# Altium schematic sheet TUCH-ALM.SchDoc(TUCH-ALM)
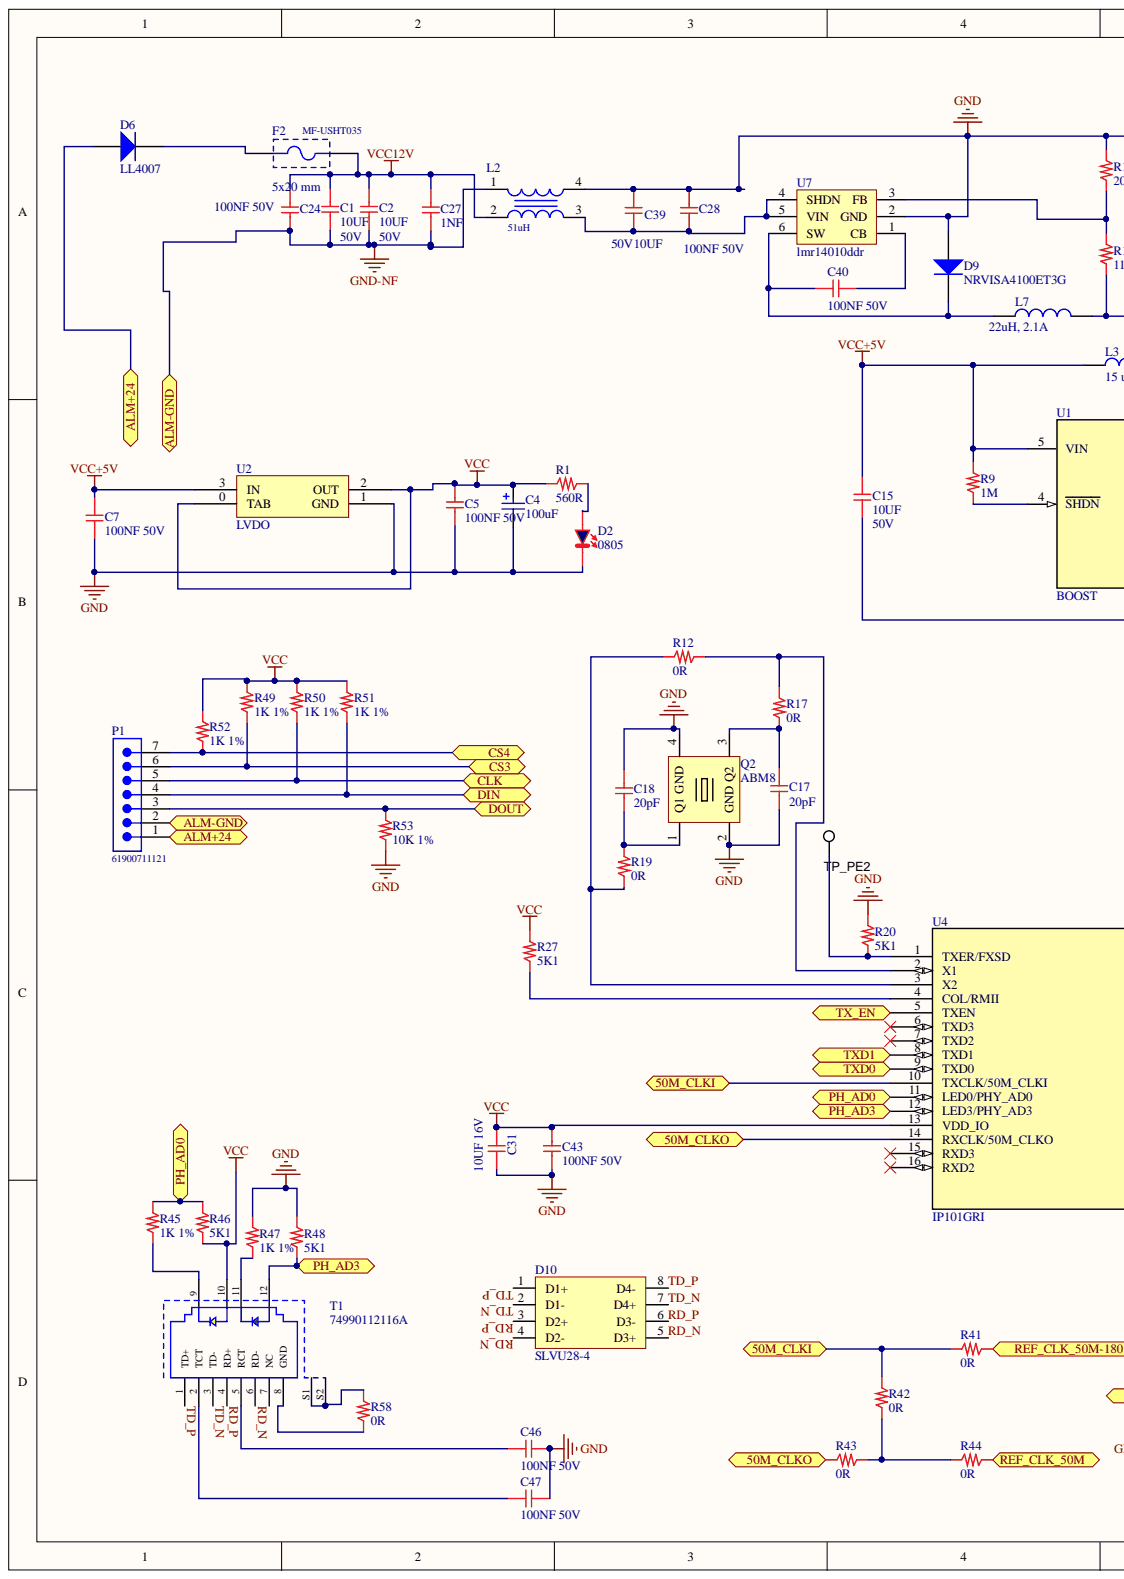
[diagram p0: TUCH-ALM.SchDoc, left]
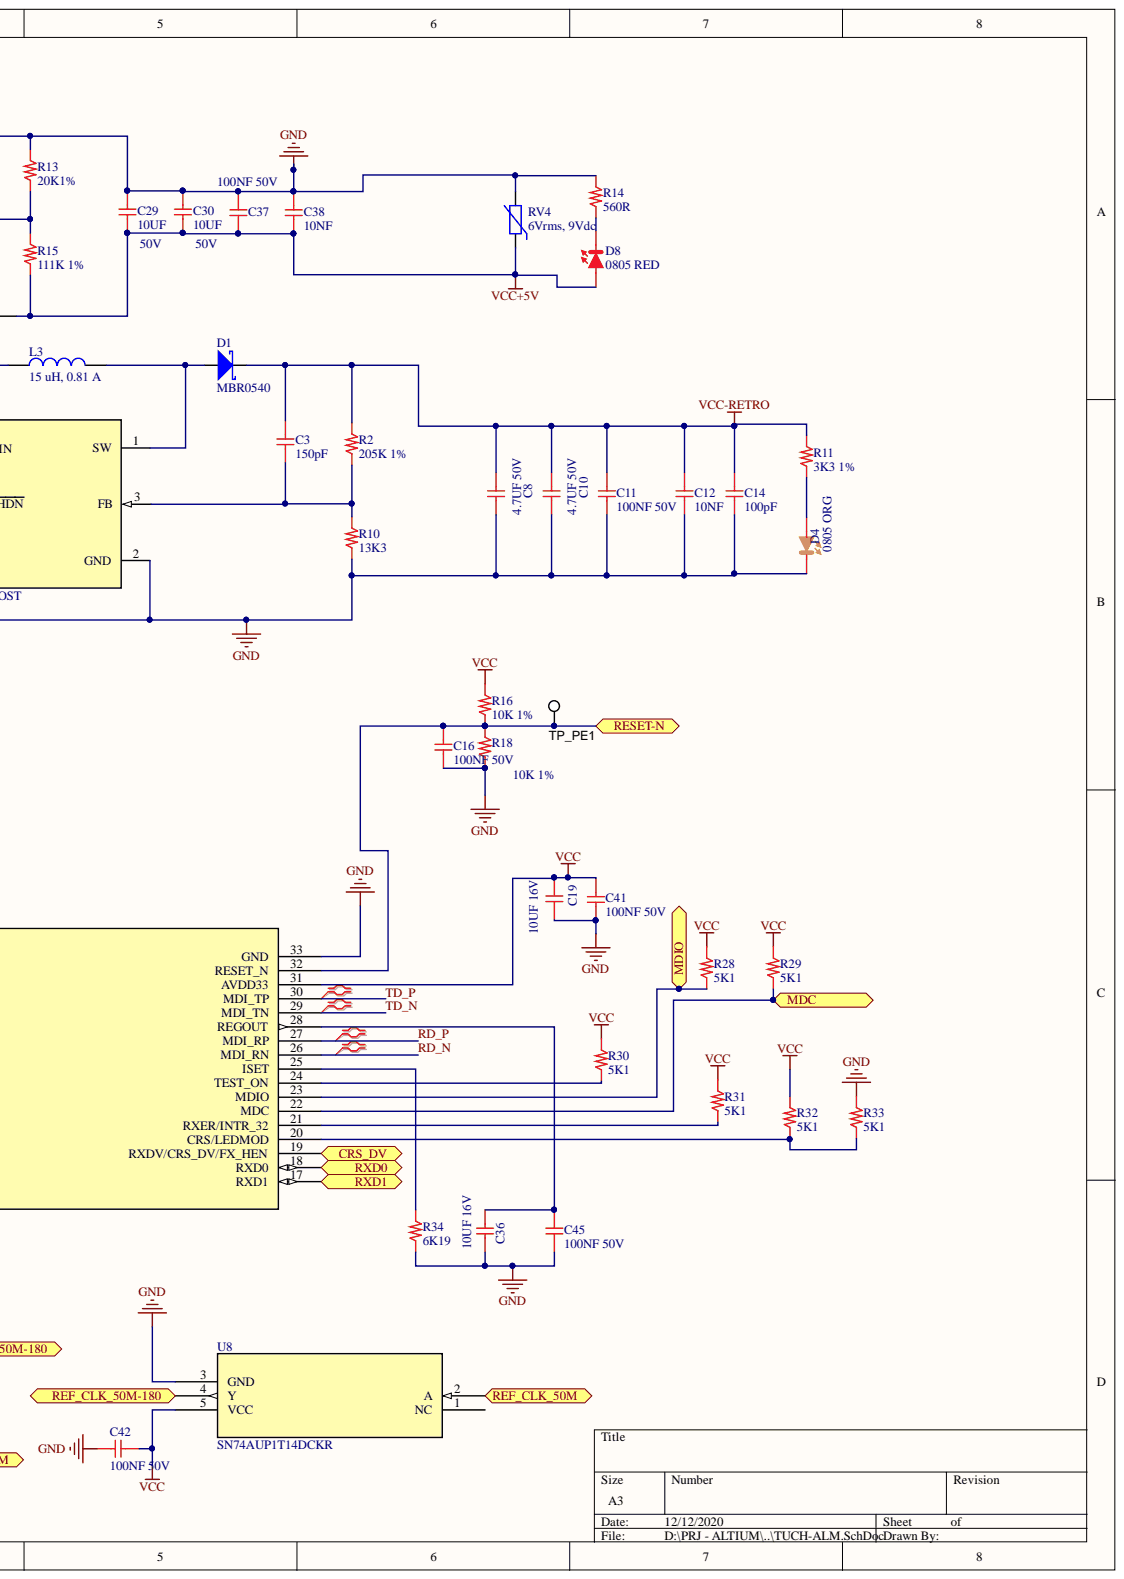
[diagram p1: TUCH-ALM.SchDoc, right]

## Parts
C1  10UF  Tensione: 50V  [1206_C]
C2  10UF  Tensione: 50V  [1206_C]
C3  150pF  [0603_c]
C4  value 100uF  [WCAP-ASLL_6.3x5.5]  (hidden comment: 865060143004)
C5  100NF 50V  [0603_c]
C7  100NF 50V  [0603_c]
C8  4.7UF 50V  [0805_C]
C10  4.7UF 50V  [0805_C]
C11  100NF 50V  [0603_c]
C12  10NF  [0603_c]
C14  100pF  [0603_c]
C15  10UF  Tensione: 50V  [1206_C]
C16  100NF 50V  [0603_c]
C17  20pF  [0402_C]
C18  20pF  [0402_C]
C19  10UF 16V  [0805_C]
C24  100NF 50V  [0603_c]
C27  1NF  [0603_c]
C28  100NF 50V  [0603_c]
C29  10UF  Tensione: 50V  [1206_C]
C30  10UF  Tensione: 50V  [1206_C]
C31  10UF 16V  [0805_C]
C36  10UF 16V  [0805_C]
C37  100NF 50V  [0603_c]
C38  10NF  [0603_c]
C39  10UF  Tensione: 50V  [1206_C]
C40  100NF 50V  [0603_c]
C41  100NF 50V  [0603_c]
C42  100NF 50V  [0603_c]
C43  100NF 50V  [0603_c]
C45  100NF 50V  [0603_c]
C46  100NF 50V  [0603_c]
C47  100NF 50V  [0603_c]
D1  MBR0540  [SMB]
D2  0805  [0805-BLU]
D4  0805 ORG  [0805_Orange]
D6  LL4007  [LL4007]
D8  0805 RED  [0805_Red]
D9  NRVISA4100ET3G  [DO-214AC -B]
D10  SLVU28-4  [SO-8]
F2  MF-USHT035  [MF-USHT035]
L2  51uH  [WE-SL2]
L3  15 uH, 0.81 A  Rated Current: 0.81 A  [WE-LQS_3012]
L7  22uH, 2.1A  Rated Current: 2.1A  [WE-LQS_8040]
P1  61900711121  [61900711121]
Q2  ABM8  [ABM8]
R1  560R  [0603_R]
R2  205K 1%  [0603_R]
R9  1M  [0603_R]
R10  13K3  [0603_R]
R11  3K3 1%  [0805_R]
R12  0R  [0402_R]
R13  20K1%  [0603_R]
R14  560R  [0603_R]
R15  111K 1%  [0603_R]
R16  10K 1%  [0603_R]
R17  0R  [0402_R]
R18  10K 1%  [0603_R]
R19  0R  [0402_R]
R20  5K1  [0402_R]
R27  5K1  [0402_R]
R28  5K1  [0402_R]
R29  5K1  [0402_R]
R30  5K1  [0402_R]
R31  5K1  [0402_R]
R32  5K1  [0402_R]
R33  5K1  [0402_R]
R34  6K19  [0402_R]
R41  0R  [0402_R]
R42  0R  [0402_R]
R43  0R  [0402_R]
R44  0R  [0402_R]
R45  1K 1%  [0603_R]
R46  5K1  [0603_R]
R47  1K 1%  [0603_R]
R48  5K1  [0603_R]
R49  1K 1%  [0603_R]
R50  1K 1%  [0603_R]
R51  1K 1%  [0603_R]
R52  1K 1%  [0603_R]
R53  10K 1%  [0603_R]
R58  0R  [0603_R]
RV4  6Vrms, 9Vdc  [WE-VS_0805]
T1  74990112116A  [74990112116A]
TP_PE1  5012  [Keystone5012]  (value not drawn)
TP_PE2  5012  [Keystone5012]  (value not drawn)
U1  BOOST  [SOT23-5_N]
U2  LVDO  [SOT-223]
U4  IP101GRI  [QFN_IP101GRI_WIZ-M]
U7  lmr14010ddr  [SOT23-6_N]
U8  SN74AUP1T14DCKR  [DCK5]

## Ports
50M_CLKI, 50M_CLKO, ALM+24, ALM-GND, CLK, CRS_DV, CS3, CS4, DIN, DOUT, MDC, MDIO, PH_AD0, PH_AD3, REF_CLK_50M, REF_CLK_50M-180, RESET-N, RXD0, RXD1, TX_EN, TXD0, TXD1

## Nets
GND: C4.2 C5.1 C7.1 C8.2 C10.2 C11.2 C12.2 C14.2 C15.2 C16.1 C17.2 C18.1 C19.2 C28.2 C29.2 C30.2 C31.2 C36.2 C37.2 C38.2 C39.1 C41.1 C42.2 C43.1 C45.1 C46.1 C47.1 D2.K D4.K D9.2 L2.4 Q2.2(GND) Q2.4(GND) R10.2 R13.1 R14.1 R18.2 R20.1 R33.1 R34.2 R47.1 R48.1 R53.2 RV4.2 U1.2(GND) U2.1(GND) U4.33(GND) U7.2(GND) U8.3(GND)
VCC: C4.1 C5.2 C19.1 C31.1 C41.2 C42.1 C43.2 R1.1 R16.1 R27.1 R28.1 R29.1 R30.1 R31.1 R32.1 R46.2 R49.1 R50.1 R51.1 R52.1 T1.10 U2.0(TAB) U2.2(OUT) U4.13(VDD_IO) U4.31(AVDD33) U8.5(VCC)
VCC12V: C1.1 C2.1 C24.2 C27.1 F2.2 L2.2
VCC+5V: C7.2 C15.1 C29.1 C30.1 C37.1 C38.1 D8.A L3.1 L7.2 R9.1 R15.2 RV4.1 U1.5(VIN) U2.3(IN)
VCC-RETRO: C3.1 C8.1 C10.1 C11.1 C12.1 C14.1 D1.K R2.1 R11.1
50M_CLKI: R41.1 R42.1 U4.10(TXCLK/50M_CLKI) ; port 50M_CLKI
50M_CLKO: R43.1 U4.14(RXCLK/50M_CLKO) ; port 50M_CLKO
ALM+24: D6.1 P1.1 ; port ALM+24
CLK: P1.5 R50.2 ; port CLK
CRS_DV: U4.19(RXDV/CRS_DV/FX_HEN) ; port CRS_DV
CS3: P1.6 R49.2 ; port CS3
CS4: P1.7 R52.2 ; port CS4
DIN: P1.4 R51.2 ; port DIN
DOUT: P1.3 R53.1 ; port DOUT
GND-NF: C1.2 C2.2 C24.1 C27.2 L2.1 P1.2 ; port ALM-GND
MDC: R29.2 U4.22(MDC) ; port MDC
MDIO: R28.2 U4.23(MDIO) ; port MDIO
PH_AD0: R45.1 R46.1 U4.11(LED0/PHY_AD0) ; port PH_AD0
PH_AD3: R48.2 T1.12 U4.12(LED3/PHY_AD3) ; port PH_AD3
RD_N: D10.4(D2-) D10.5(D3+) T1.6(RD-) U4.26(MDI_RN)
RD_P: D10.3(D2+) D10.6(D3-) T1.4(RD+) U4.27(MDI_RP)
REF_CLK_50M: R44.2 U8.2(A) ; port REF_CLK_50M
REF_CLK_50M-180: R41.2 U8.4(Y) ; port REF_CLK_50M-180
RESET-N: C16.2 R16.2 R18.1 TP_PE1.1 U4.32(RESET_N) ; port RESET-N
RXD0: U4.18(RXD0) ; port RXD0
RXD1: U4.17(RXD1) ; port RXD1
TD_N: D10.2(D1-) D10.7(D4+) T1.3(TD-) U4.29(MDI_TN)
TD_P: D10.1(D1+) D10.8(D4-) T1.1(TD+) U4.30(MDI_TP)
TX_EN: U4.5(TXEN) ; port TX_EN
TXD0: U4.9(TXD0) ; port TXD0
TXD1: U4.8(TXD1) ; port TXD1
NetC3_2: C3.2 R2.2 R10.1 U1.3(FB)
NetC17_1: C17.1 Q2.3 R17.1
NetC18_2: C18.2 Q2.1(Q1) R19.2
NetC28_1: C28.1 C39.2 L2.3 U7.4(SHDN) U7.5(VIN)
NetC36_1: C36.1 C45.2 U4.28(REGOUT)
NetC40_1: C40.1 D9.1 L7.1 U7.6(SW)
NetC40_2: C40.2 U7.1(CB)
NetC46_2: C46.2 T1.5(RCT)
NetC47_2: C47.2 T1.2(TCT)
NetD1_A: D1.A L3.2 U1.1(SW)
NetD2_A: D2.A R1.2
NetD4_A: D4.A R11.2
NetD6_2: D6.2 F2.1
NetD8_K: D8.K R14.2
NetR9_2: R9.2 U1.4(SHDN)
NetR12_1: R12.1 R19.1 U4.3(X2)
NetR12_2: R12.2 R17.2 U4.2(X1)
NetR13_2: R13.2 R15.1 U7.3(FB)
NetR20_2: R20.2 TP_PE2.1 U4.1(TXER/FXSD)
NetR27_2: R27.2 U4.4(COL/RMII)
NetR30_2: R30.2 U4.24(TEST_ON)
NetR31_2: R31.2 U4.21(RXER/INTR_32)
NetR32_2: R32.2 R33.2 U4.20(CRS/LEDMOD)
NetR34_1: R34.1 U4.25(ISET)
NetR42_2: R42.2 R43.2 R44.1
NetR45_2: R45.2 T1.9
NetR47_2: R47.2 T1.11
NetR58_1: R58.1 T1.S1 T1.S2
NetR58_2: R58.2 T1.8(GND)

Not connected: T1 7(NC); U4 6(TXD3) 7(TXD2) 15(RXD3) 16(RXD2); U8 1(NC)

## Library data of the parts
C1 C2 C15 C29 C30 C39 = 10UF 50V: Cap X5R 10UF 50V; Produttore: MURATA; Cod. Produttore: GRM31CR61H106KA12L; Temperature Range: Da -55°C a +125°C; Library Name: CapSMD1206.SchLib; Component Kind: Standard; Cod. Farnell: 2666583
C3 = 150pF 50V: Cap X7R 150pF 50V; Produttore: ??; Cod. Interno: COCS??; Library Name: CapSMD0603.SchLib; Component Kind: Standard; Cod. Produttore: ??
C4 = 865060143004: WCAP-ASLL Aluminum Electrolytic Capacitors, size Ф6.3x5.5mm, 6.3V DC, 100uF; Rated Voltage: 6.3V DC; Size: Ф6.3x5.5mm; Published Date: June 2017; Part Number: 865060143004; Operating Temperature: -55 °C up to +105 °C; Life Cycle: 2000h; Library Name: WCAP-ASLL.SchLib; ComponentLink2URL: http://katalog.we-online.de/pbs/datasheet/865060143004.pdf; ComponentLink2Description: Datasheet; ComponentLink1URL: http://www.we-online.de; ComponentLink1Description: Manufacturer; Component Kind: Standard; Publisher: Wurth Elektronik
C5 C7 C11 C16 C24 C28 C37 C40-C43 C45-C47 = 100NF 50V: Cap X7R 100nF 50V Flex Terminal; Produttore: Samsung; Cod. Produttore: CL10B104KB8SFNC; Cod. Interno: COCS50665050104; Library Name: CapSMD0603.SchLib; Component Kind: Standard; Cod. DigiKey: 1276-1936-2-ND
C8 C10 = 4.7UF 50V: Cap X5R 4.7UF 50V; Produttore: TDK; Cod. Produttore: C2012X5R1H475K; Cod. Interno: COCS517065000475; Temperature Range: Da -55°C a +105°C; Library Name: CapSMD0805.SchLib; Component Kind: Standard
C12 C38 = 10NF 50V: Cap X7R 10nF 50V; Produttore: Samsung; Cod. Produttore: CL05B103KB5NNNC; Cod. Interno: COCS 556655000103; Library Name: CapSMD0603.SchLib; Component Kind: Standard; Cod. DigiKey: 1276-1028-2-ND
C14 = 100pF 50V: Cap X7R 100pF 50V; Produttore: ??; Cod. Interno: COCS??; Library Name: CapSMD0603.SchLib; Component Kind: Standard; Cod. Produttore: ??
C17 C18 = 20pF 50V: Cap COG 10pF 50V; Produttore: ??; Cod. Interno: COCS506565000100; Library Name: CapSMD0402.SchLib; Component Kind: Standard; Cod. Produttore: ??
C19 C31 C36 = 10UF 16V: Cap X5R 10UF 16V; Produttore: TDK; Cod. Produttore: C2012X6S1C106K125AC; Cod. Interno: COCS517061600106; Temperature Range: Da -55°C a +105°C; Library Name: CapSMD0805.SchLib; Component Kind: Standard; Cod. DigiKey: 445-7611-2-ND
C27 = 1NF 50V: Cap X7R 1nF 50V; Cod. Interno: COCS 50665050102; Library Name: CapSMD0603.SchLib; Component Kind: Standard
D1 = MBR0540: Schottky Diode; Simulation: SKYDIODE; Published: 8-Jun-2000; PackageReference: SMB; PackageDescription: DO-214-AA/SMB; 2 C-Bend Leads; Body 5.3 x 3.6 mm, inc. leads (LxW); LatestRevisionNote: Re-released for DXP Platform.; LatestRevisionDate: 17-Jul-2002; Component Kind: Standard; Code_JEDEC: DO-214-AA; Publisher: Altium Limited; Library Name: HSW.SchLib
D2 = LED BLU 0805: Vf(typ): 3.3V; Produttore: kingbright; Luminosità: 100mcd 20mA; Cod. Produttore: KPTR-3216QBC-D; Description: LED BLU 0805; Component Kind: Standard; Library Name: DiodiLED.SchLib
D4 = LED_Arancio_0805: LED arancio 0805; V: 2.0V; Produttore: WE; Luminosità: 120mcd; Cod. Produttore: 150080YS75000; Cod. Interno: LEDS DAC080YS7500; Component Kind: Standard; Library Name: DiodiLED.SchLib
D6 = LL4007: High Conductance Fast Diode; Published: 8-Jun-2000; PackageReference: DO-35; PackageDescription: Diode, Axial; Body 3.8 x 1.9 mm (LxDia. max), Lead Dia. 0.53 mm (max); LatestRevisionNote: Re-released for DXP Platform.; LatestRevisionDate: 17-Jul-2002; DatasheetVersion: 1997; ComponentLink2URL: http://www.fairchildsemi.com/ds/1N%2F1N4148.pdf; ComponentLink2Description: Datasheet; ComponentLink1URL: http://www.fairchildsemi.com/; ComponentLink1Description: Manufacturer Link; Component Kind: Standard; Code_JEDEC: DO-204-AH; Publisher: Altium Limited; Library Name: HSW.SchLib
D8 = LED_ROSSO_0805: LED Rosso 0805; V: 2.0V; Produttore: WE; Luminosità: 150mcd; Cod. Produttore: 150080RS75000; Cod. Interno: LEDS 2AC080RS7500; Component Kind: Standard; Library Name: DiodiLED.SchLib
D9 = BYS-10-45: High Conductance Fast Diode; Published: 8-Jun-2000; PackageReference: DO-35; PackageDescription: Diode, Axial; Body 3.8 x 1.9 mm (LxDia. max), Lead Dia. 0.53 mm (max); LatestRevisionNote: Re-released for DXP Platform.; LatestRevisionDate: 17-Jul-2002; DatasheetVersion: 1997; ComponentLink2URL: http://www.fairchildsemi.com/ds/1N%2F1N4148.pdf; ComponentLink2Description: Datasheet; ComponentLink1URL: http://www.fairchildsemi.com/; ComponentLink1Description: Manufacturer Link; Component Kind: Standard; Code_JEDEC: DO-204-AH; Publisher: Altium Limited; Library Name: HSW.SchLib
D10 = SLVU28-4: SLVU28-4 ARREY TVS; Component Kind: Standard; Library Name: HSW.SchLib
F2 = MF-USHT035: MF-USHT035 POLIFUSE; Part Number: 696108003002; Fuse Size: 5x20 mm; Published Date: August 2018; Packaging: Box; Operating Temperature: -30 to 120 degC; Mounting Technology: THT; ComponentLink2URL: https://katalog.we-online.de/em/datasheet/696108003002.pdf; ComponentLink2Description: Datasheet; ComponentLink1URL: http://www.we-online.de; ComponentLink1Description: Manufacturer; Component Kind: Standard; Publisher: Wurth Elektronik; Library Name: HSW.SchLib
L2 = 744227S: WE-SL2 SMT Common Mode Line Filter, 51uH, 1000mA, 80V; Winding Style: sectional; Leakage Inductance: 3150nH; Insulation Test Voltage: 500V (AC); Impedance max.: 5500Ohm; DC Resistance max.: 0.16Ohm; Rated Voltage: 80V; Rated Current: 1000mA; Published Date: March 2019; Part Number: 744227S; Packaging: Tape and Reel; Operating Temperature: -40 to +125degC; Mounting Technology: SMT; Library Name: WE-SL2.SchLib; Inductance: 51uH; ComponentLink2URL: https://katalog.we-online.com/pbs/datasheet/744227S.pdf; ComponentLink2Description: Datasheet; ComponentLink1URL: http://www.we-online.de; ComponentLink1Description: Manufacturer; Component Kind: Standard; Publisher: Wurth Elektronik
L3 = 74404031150A: SMT Semi-Shielded Power Inductor WE-LQS, size 3012, 15 uH, 0.81 A; Self Resonant Frequency: 46 MHz; Saturation Current: 0.53 A; Inductance: 15 uH; DC Resistance max.: 483.6 mOhm; Size: 3012; Published Date: November 2018; Part Number: 74404031150A; Packaging: Tape and Reel; Operating Temperature: -40 to +125 degC; Mounting Technology: SMT; Library Name: WE-LQS_3012.SchLib; ComponentLink2URL: https://katalog.we-online.de/pbs/datasheet/74404031150A.pdf; ComponentLink2Description: Datasheet; ComponentLink1URL: http://www.we-online.de; ComponentLink1Description: Manufacturer; Component Kind: Standard; Publisher: Wurth Elektronik
L7 = 74404084220: WE-LQS SMD Power Inductor, size 8040, 22uH, 2.1A; Self Resonant Frequency: 15MHz; Saturation Current: 2.6A; Inductance Tolerance: +/-20%; DC Resistance Tolerance: +/-30%; DC Resistance: 69mOhm; Size: 8040; Published Date: May 2019; Part Number: 74404084220; Packaging: Tape and Reel; Operating Temperature: -40 to +125degC; Mounting Technology: SMT; Library Name: WE-LQS_8040.SchLib; Inductance: 22uH; ComponentLink2URL: https://katalog.we-online.com/pbs/datasheet/74404084220.pdf; ComponentLink2Description: Datasheet; ComponentLink1URL: http://www.we-online.de; ComponentLink1Description: Manufacturer; Component Kind: Standard; Publisher: Wurth Elektronik
P1 = 61900711121: Male Locking Header WR-WTB, THT, Vertical, pitch 2.54 mm, 1 x 7 position; Working Voltage: 250 VAC; Position: 1 x 7; Pitch: 2.54 mm; Contact Resistance: 20 mOhm; Published Date: September 2018; Part Number: 61900711121; Packaging: Bag; Orientation: Vertical; Operating Temperature: -40 to 105 degC; Mounting Technology: THT; Library Name: WR-WTB_2.54mm.SchLib; Gender: Male; ComponentLink2URL: https://katalog.we-online.de/em/datasheet/6190xx11121.pdf; ComponentLink2Description: Datasheet; ComponentLink1URL: http://www.we-online.de; ComponentLink1Description: Manufacturer; Component Kind: Standard; Publisher: Wurth Elektronik
Q2 = ABM8-25MHZ: QUARZO 25MHZ; Component Kind: Standard; Library Name: HSW.SchLib
R1 R14 = 560R: Res Film Spesso 1/16W 560R 5%; Cod. Interno: RESS 4166556B0561; Library Name: ResSMD0603.SchLib; Component Kind: Standard
R2 = 205K 1%: Res Film Spesso 1/16W 205K 1%; Produttore: ??; Cod. Interno: RESS4166360C0104; Library Name: ResSMD0603.SchLib; Component Kind: Standard; Cod. Produttore: ??
R9 = 1M: Res Film Spesso 1/16W 1M 5%; Produttore: ??; Cod. Interno: RESS4166556B0105??; Library Name: ResSMD0603.SchLib; Component Kind: Standard; Cod. Produttore: ??
R10 = 13K3: Res Film Spesso 1/16W 13K3  1%; Produttore: ??; Library Name: ResSMD0603.SchLib; Component Kind: Standard; Cod. Produttore: ??
R11 = 3K3 1%: Res Film Spesso 0805 1/2W 3K3 1%; Cod. Interno: ??; Library Name: ResSMD0805.SchLib; Component Kind: Standard
R12 R17 R19 R41-R44 = 0R: Res Film Spesso 1/16W 0R 5%; Produttore: ??; Cod. Interno: RESS4165560C0000; Library Name: ResSMD0402.SchLib; Component Kind: Standard; Cod. Produttore: ??
R13 R15 = 110K 1%: Res Film Spesso 1/16W 110K 1%; Library Name: ResSMD0603.SchLib; Component Kind: Standard
R16 R18 R53 = 10K 1%: Res Film Spesso 1/16W 10K 1%; Produttore: Panasonic; Cod. Produttore: ERJ-3EKF1002V; Cod. Interno: RESS4166356B0103; Library Name: ResSMD0603.SchLib; Component Kind: Standard
R20 R27-R33 = 5K1: Res Film Spesso 1/16W 5K1 5%; Produttore: ??; Cod. Interno: RESS4165560C0103; Library Name: ResSMD0402.SchLib; Component Kind: Standard; Cod. Produttore: ??
R34 = 6K19: Res Film Spesso 1/16W 6K19 5%; Produttore: ??; Cod. Interno: RESS4165560C0103; Library Name: ResSMD0402.SchLib; Component Kind: Standard; Cod. Produttore: ??
R45 R47 R49-R52 = 1K 1%: Res Film Spesso 1/16W 1K 1%; Produttore: ??; Cod. Interno: RESS4166356B0102; Library Name: ResSMD0603.SchLib; Component Kind: Standard; Cod. Produttore: ??
R46 R48 = 5K1: Res Film Spesso 1/16W 5K1 5%; Produttore: Yageo; Cod. Produttore: RC0603JR-075K6L; Cod. Interno: RESS4166556B0562; Library Name: ResSMD0603.SchLib; Component Kind: Standard
R58 = 0R: Res Film Spesso 1/16W 0R 5%; Cod. Interno: RESS 4166556B0000; Library Name: ResSMD0603.SchLib; Component Kind: Standard
RV4 = 82550060: WE-VS SMD Varistor, size 0805, 6Vrms, 9Vdc; Withstanding Surge Current: 80A; Varistor Voltage: 12V; Energy Dissipation: 0.1J; Clamping Voltage: 20V; Size: 0805; Published Date: March 2019; Part Number: 82550060; Packaging: Tape and Reel; Operating Temperature: -40 to +85degC; Mounting Technology: SMT; Library Name: WE-VS_0805.SchLib; DC Operating Voltage: 9Vdc; ComponentLink2URL: https://katalog.we-online.com/pbs/datasheet/82550060.pdf; ComponentLink2Description: Datasheet; ComponentLink1URL: http://www.we-online.de; ComponentLink1Description: Manufacturer; Component Kind: Standard; AC Operating Voltage: 6Vrms; Publisher: Wurth Elektronik
T1 = 74990112116A: WE-RJ45 LAN Transformer, THT, 10/100 Base-T, Port 1x1, Tab Up; Manufacturer: Wurth Elektronik; USB: No; Shield Tabs: Yes; PoE: non-PoE; LED: green-yellow; Tab: Up; Published Date: April 2020; Port Number: 1x1; Packaging: Tube; Operating Temperature: -40 to +85degC; Mounting Technology: THT; Manufacturer Part Number: 74990112116A; Library Name: WE-RJ45 LAN.SchLib; HPLE: No; Data Rate: 10/100 Base-T; ComponentLink2URL: https://www.we-online.com/catalog/datasheet/74990112116A.pdf; ComponentLink2Description: Datasheet; ComponentLink1URL: http://www.we-online.de; ComponentLink1Description: Manufacturer; Component Kind: Standard
TP_PE1 TP_PE2 = TP: Test Point, Multipurpose, White, TH; Manufacturer: Keystone; ContactFinish: Silver; CompType: Test Point Loop; SymbolVersion: 2008-04-04; Supplier Part Number 2: 534-5012; Supplier Part Number 1: 36-5012-ND; Supplier 2: Mouser; Supplier 1: Digi-Key; RoHS: Y; PartNumber: 5012; PackageReference: White Multipurpose Testpoint; MountType: TH; LatestRevisionDate: 2017-01-19; ComponentLink1URL: http://www.keyelco.com/userAssets/file/M60-2p60.pdf; ComponentLink1Description: Datasheet URL; Component Kind: Standard; Color: White; Publisher: Texas Instruments; Library Name: HSW.SchLib
U1 = LMR62014: LMR61014 BOOST; PartNumber: TLV61046ADBVR; Manufacturer: Texas Instruments; Value: Value; Type: DEV; RefDes: RefDes; Component Kind: Standard; Library Name: HSW.SchLib; Copyright: Copyright (C) 2018 Accelerated Designs. All rights reserved
U2 = LD1117S33TR: REGOLATORE IN; Component Kind: Standard; Library Name: HSW.SchLib
U4 = IP101GRI: LAN DRIVER; Vendor: WIZnet; SourceLibrary: WIZnet_2020-06-05; Built_By: EMA_Matt; Type: DEV; RefDes: RefDes; Manufacturer_Part_Number: IP101GRI; Datasheet: https://www.datasheets360.com/pdf/1492395742319904195; Component Kind: Standard; Library Name: HSW.SchLib; Copyright: Copyright (C) 2018 Accelerated Designs. All rights reserved
U8 = SN74AUP1T14DCKR: Low Power, 1.8/2.5/3.3-V In, 3.3-V CMOS Out Single Schmitt-Trigger Inverter Gate; Vendor: Texas Instruments; PIN_COUNT: 5; PACKAGE_DESIGNATOR: DCK; INDUSTRY_STD_PKG_TYPE: SC70; FAMILY_NAME: LL VOLTAGE LEVEL TRANSLATION; Type: DEV; RefDes: RefDes; Manufacturer_Part_Number: SN74AUP1T14DCKR; Manufacturer: Texas Instruments; GENERIC_PART_NUMBER: SN74AUP1T14; Datasheet_URL: http://www.ti.com/lit/gpn/SN74AUP1T14; Component Kind: Standard; Library Name: HSW.SchLib; Copyright: Copyright (C) 2018 Accelerated Designs. All rights reserved
U7 = lmr14010ddr: Component Kind: Standard; Library Name: HSW.SchLib
